# Altium schematic sheet Power.SchDoc
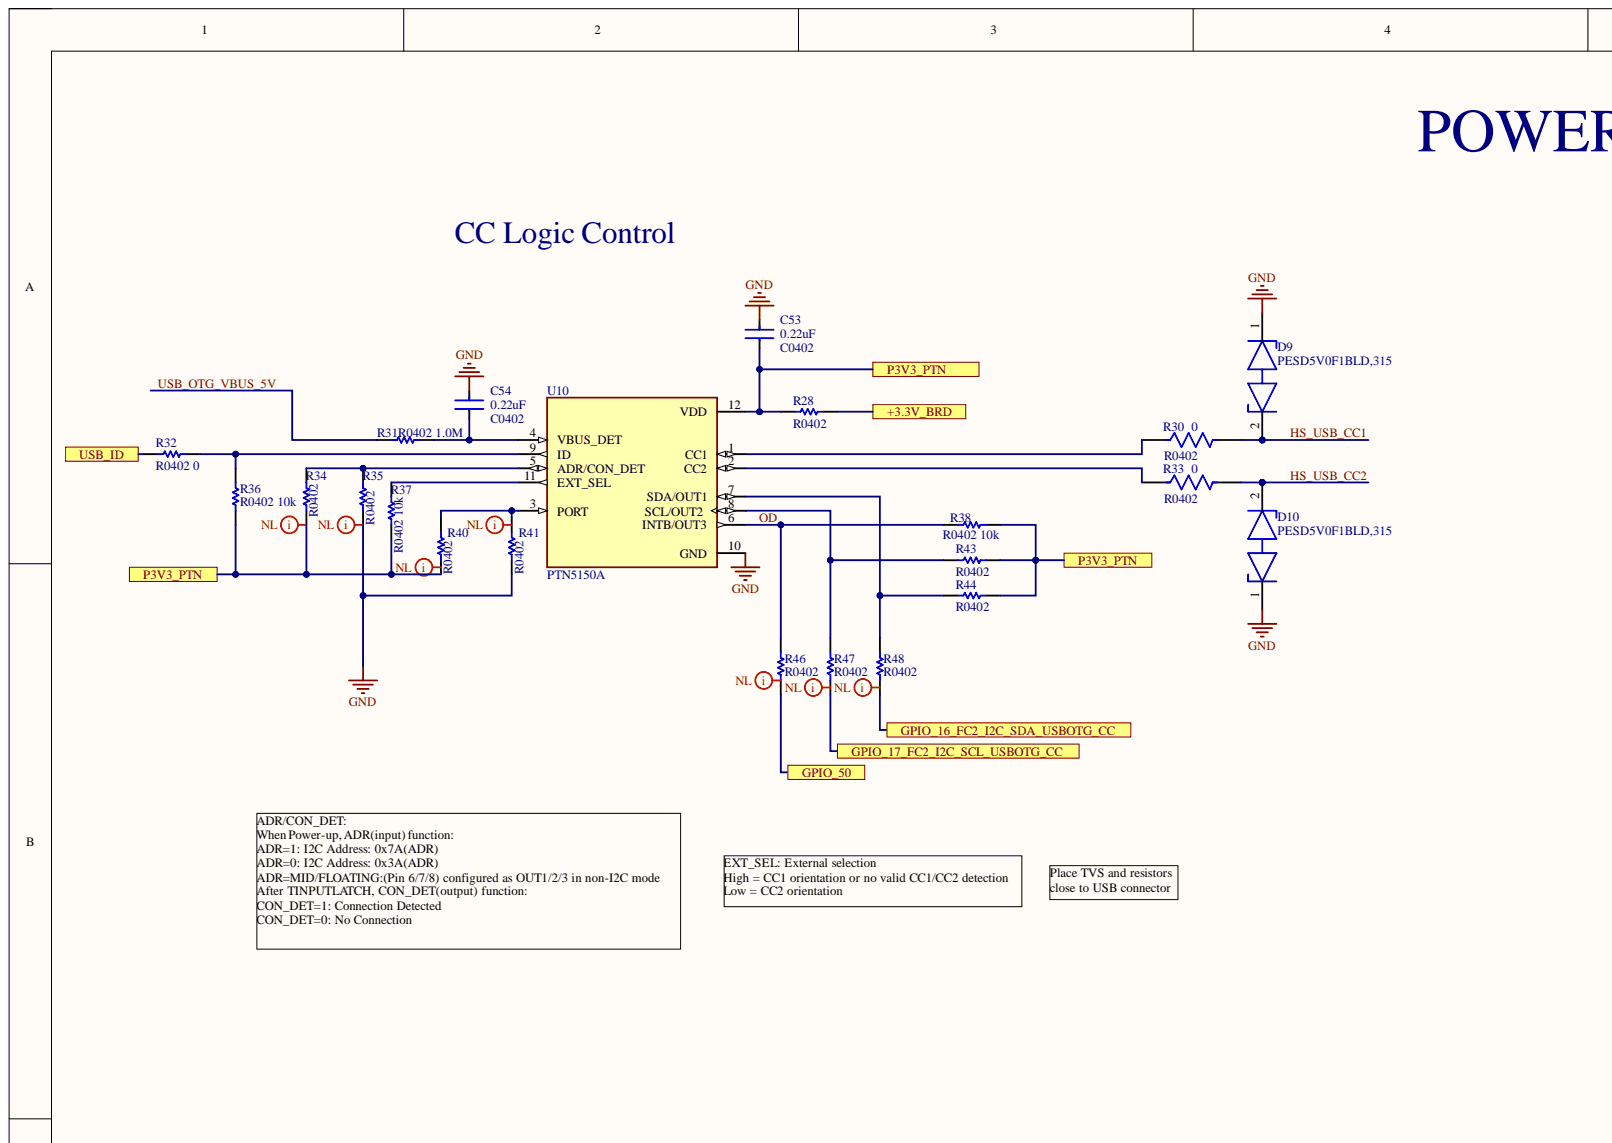
[diagram p0: Power.SchDoc, top left]
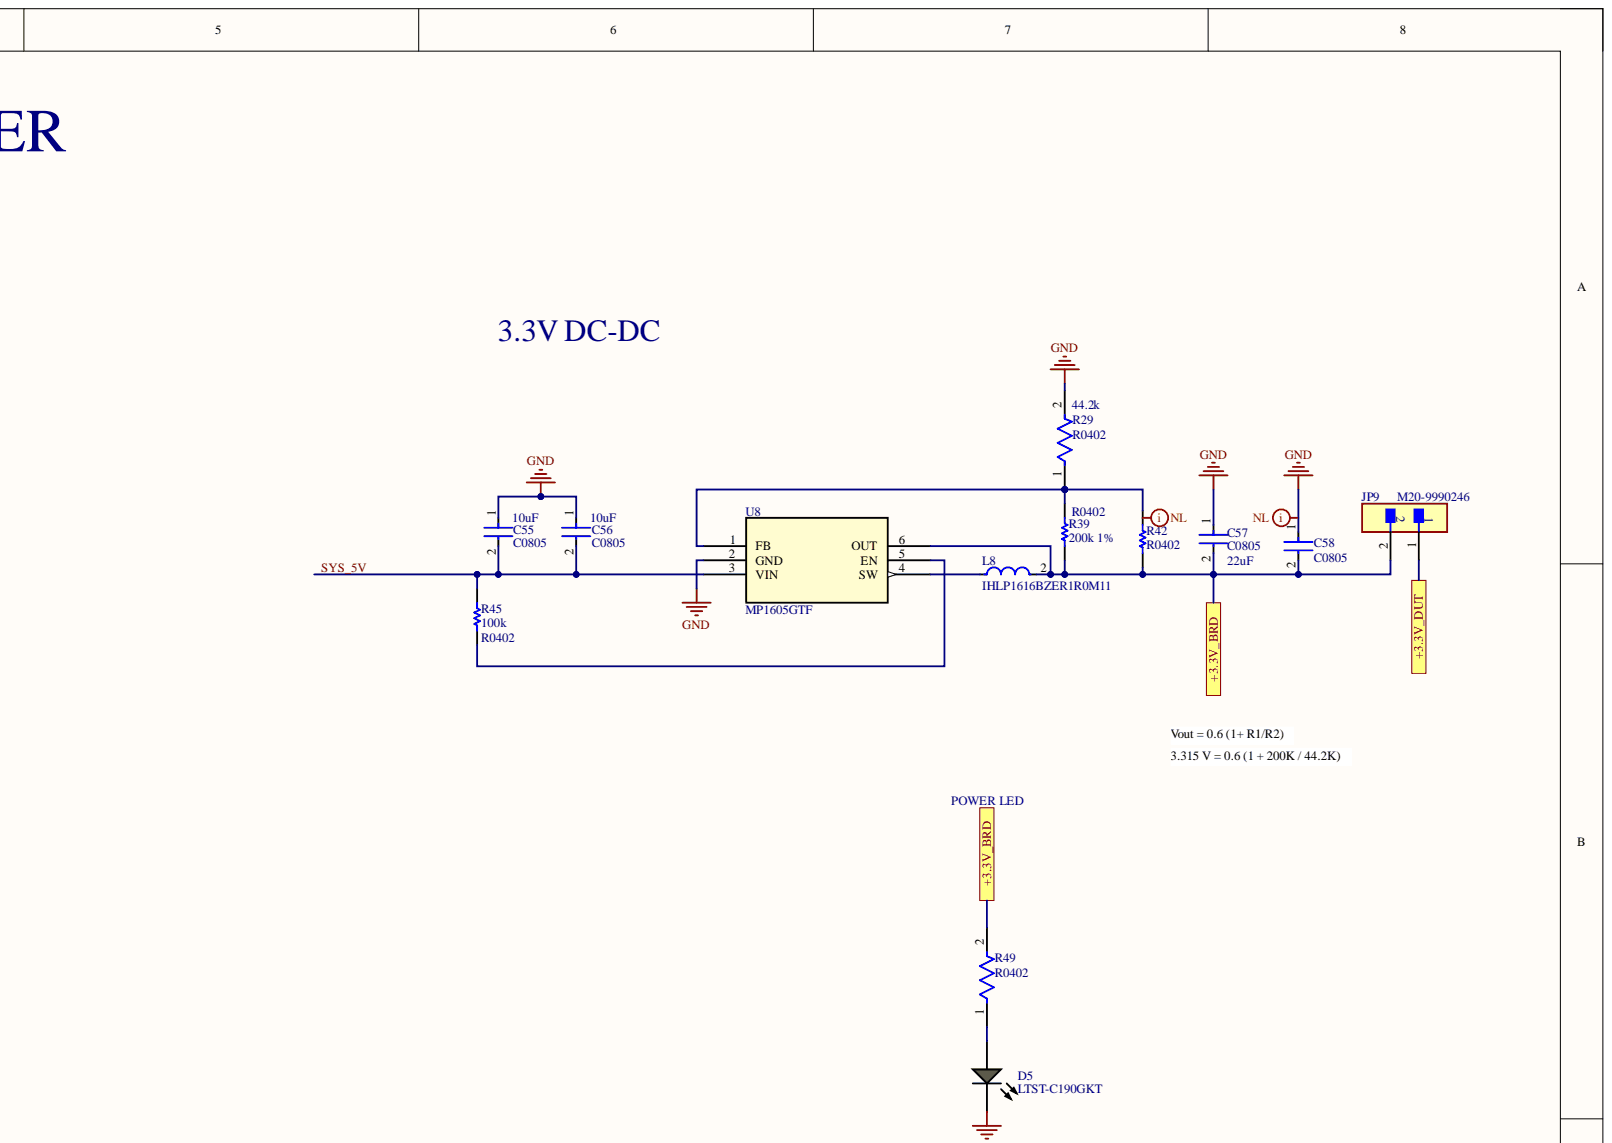
[diagram p1: Power.SchDoc, top right]
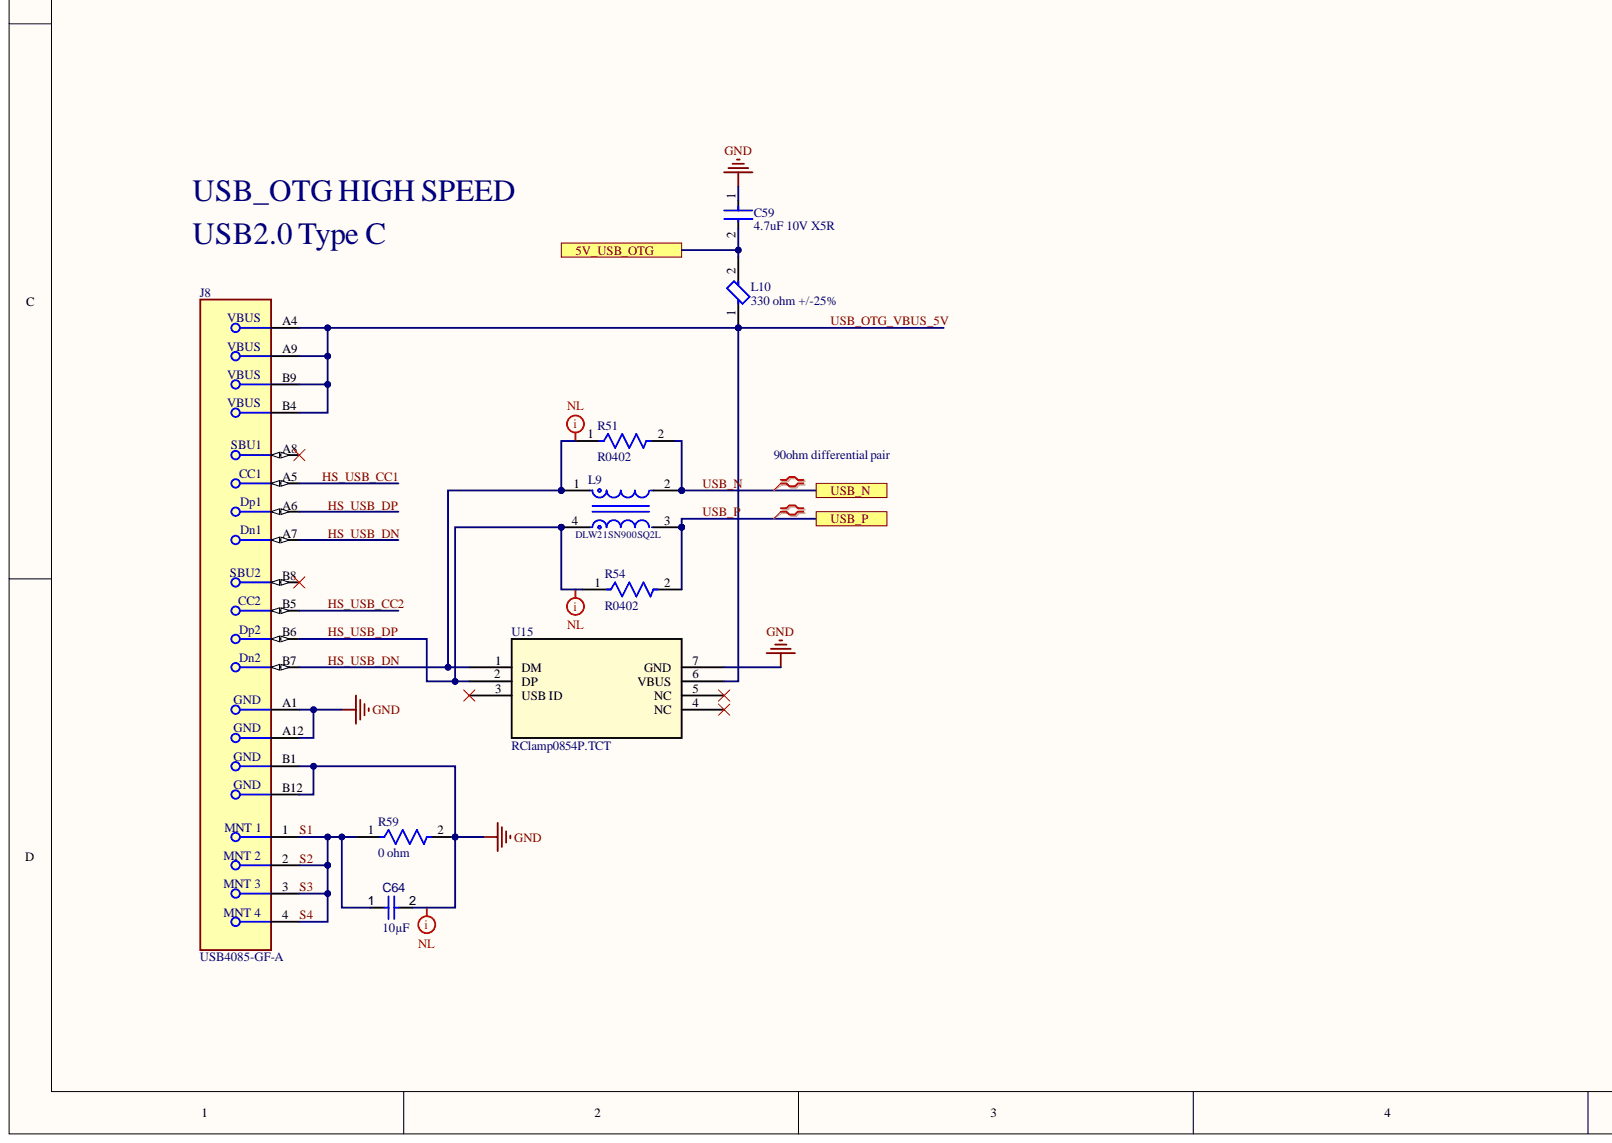
[diagram p2: Power.SchDoc, bottom left]
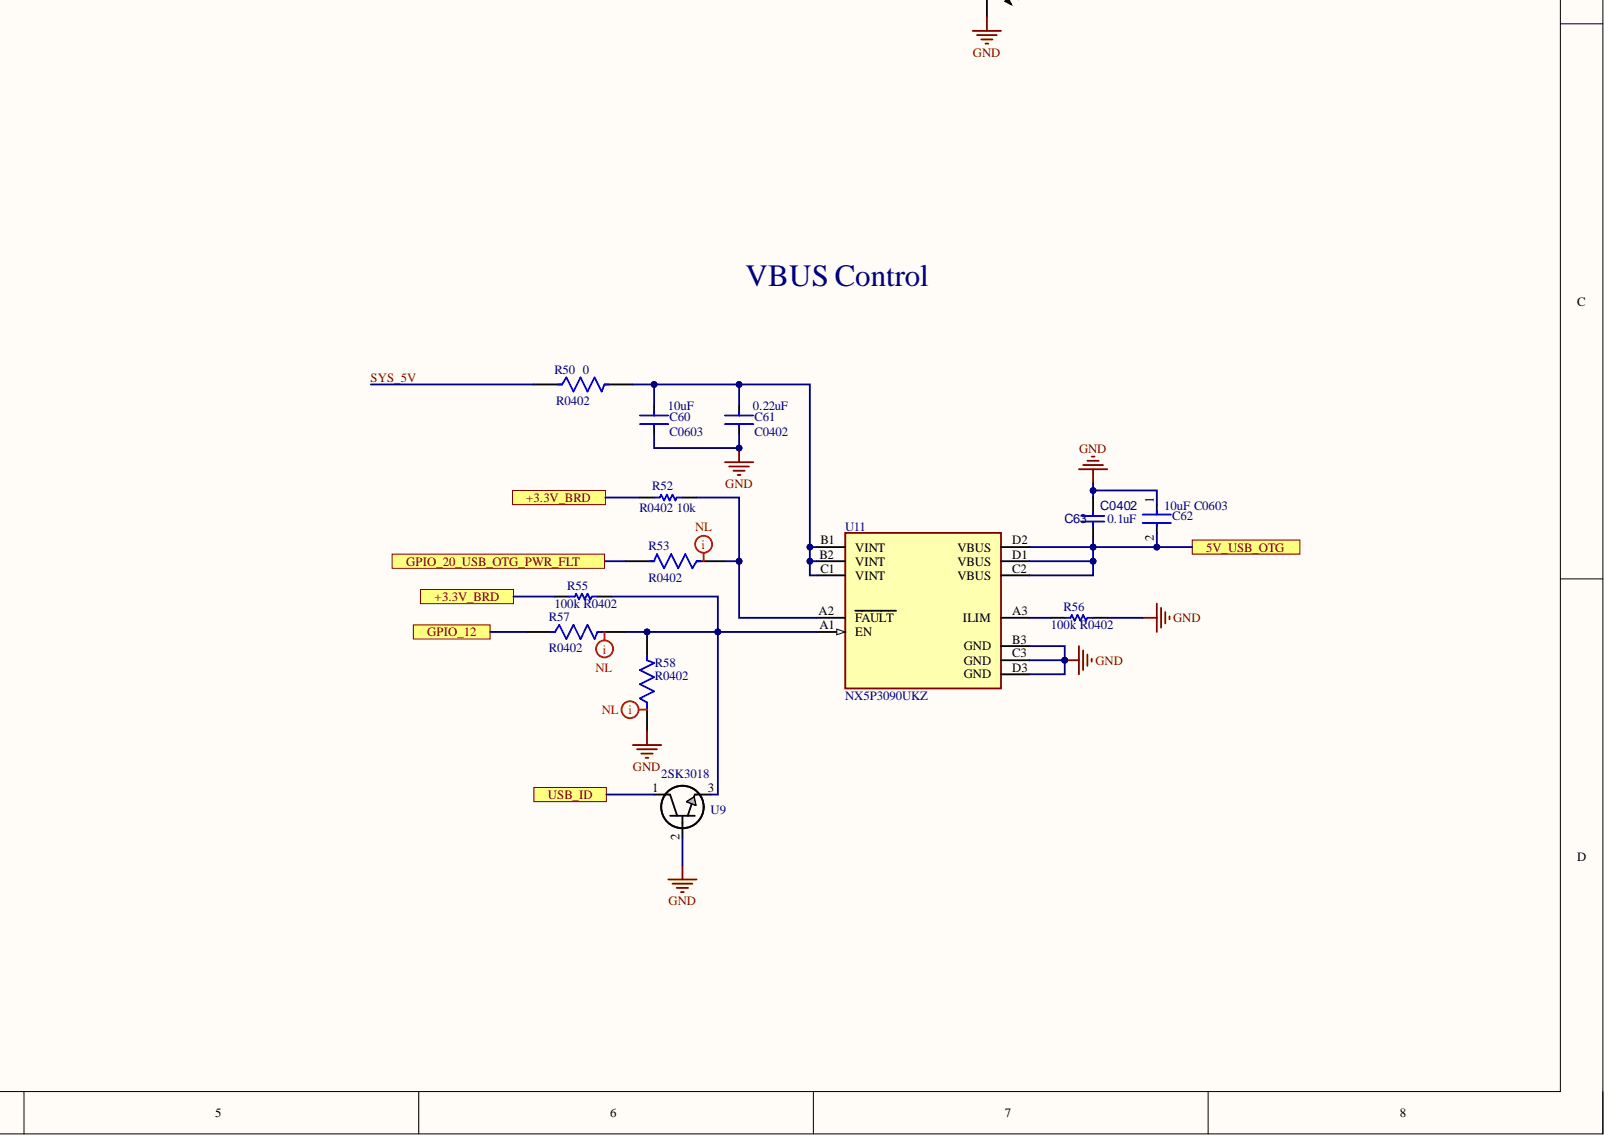
[diagram p3: Power.SchDoc, bottom right]

## Parts
C53  C0402  value 0.22uF  [FP-CC0402-MFG]
C54  C0402  value 0.22uF  [FP-CC0402-MFG]
C55  C0805  Capacitance: 10uF  [FP-CC0805-0_2-1_45-IPC_A]
C56  C0805  Capacitance: 10uF  [FP-CC0805-0_2-1_45-IPC_A]
C57  C0805  Capacitance: 22uF  [FP-C0805C226M9PACTU-MFG]
C58  C0805  [FP-C0805C226M9PACTU-MFG]
C59  4.7uF 10V X5R  Capacitance: 4.7uF  Voltage: 10V  [FP-C0805C475K8PACTU-MFG]
C60  C0603  Capacitance: 10uF  [FP-CC0603-DC-IPC_A]
C61  C0402  value 0.22uF  [FP-CC0402-MFG]
C62  10uF C0603  value 10uF  Capacitance: 10uF  [FP-C0603C106M9PACTU-MFG]
C63  C0402  value 0.1uF  [CAPC1005X56X25LL10T15]
C64  value 10µF  [CAPC2013X135X45NL10T25]  (hidden comment: Capacitor 10 uF +/- 10% 16 V 0805)
D5  LTST-C190GKT  [LTST-C190GKT_V]
D9  PESD5V0F1BLD,315  [FP-SOD882D-MFG]
D10  PESD5V0F1BLD,315  [FP-SOD882D-MFG]
J8  USB4085-GF-A  [FP-USB4085-GF-A-MFG]
JP9  M20-9990246  [FP-M20-9990246-MFG]
L8  IHLP1616BZER1R0M11  [FP-IHLP-1616BZ-FP_1_8545x-MFG]
L9  DLW21SN900SQ2L  [FP-DLW21S-MFG]
L10  330 ohm +/-25%  [FP-BLM18-0_15-t0_8-MFG]
R28  R0402  [RESC1005X40X25NL10T10]
R29  R0402  Resistance: 44.2k  [FP-CR0402-IPC_C]
R30  R0402  [FP-CR0402-IPC_B]
R31  R0402 1.0M  [RESC1005X40X25LL10T10]
R32  R0402 0  [RESC1005X40X25NL05T10]
R33  R0402  [FP-CR0402-IPC_B]
R34  R0402  [RESC1005X40X25NL05T10]
R35  R0402  [RESC1005X40X25NL05T10]
R36  R0402 10k  [RESC1005X40X25NL10T10]
R37  R0402 10k  [RESC1005X40X25NL10T10]
R38  R0402 10k  [RESC1005X40X25NL10T10]
R39  200k 1%  Part Number: R0402  [RESC1005X40X25LL10T10]
R40  R0402  [RESC1005X40X25NL05T10]
R41  R0402  [RESC1005X40X25NL05T10]
R42  R0402  [RESC1005X40X25NL10T10]
R43  R0402  [RESC1005X40X25NL10T10]
R44  R0402  [RESC1005X40X25NL10T10]
R45  100k  Part Number: R0402  [RESC1005X40X25LL10T10]
R46  R0402  [RESC1005X40X25NL10T10]
R47  R0402  [RESC1005X40X25NL10T10]
R48  R0402  [RESC1005X40X25NL10T10]
R49  R0402  [FP-CR0402-MFG]
R50  R0402  [FP-CR0402-IPC_B]
R51  R0402  [FP-CR0402-IPC_C]
R52  R0402 10k  [RESC1005X40X25NL10T10]
R53  R0402  [FP-CR0402-IPC_C]
R54  R0402  [FP-CR0402-IPC_C]
R55  100k R0402  [RESC1005X40X25LL10T10]
R56  100k R0402  [RESC1005X40X25LL10T10]
R57  R0402  [FP-CR0402-IPC_C]
R58  R0402  [FP-CR0402-IPC_C]
R59  0 ohm  [FP-CR0805-IPC_C]
U8  MP1605GTF  [MP1605GTF-Footprint-1]
U9  2SK3018  [2SK3018-Footprint-1]
U10  PTN5150A  [PTN5150A-Footprint-1]
U11  NX5P3090UKZ  [FP-SOT1390-5-IPC_C]
U15  RClamp0854P.TCT  [RClamp0854P.TCT-Footprint-1]

## Ports
5V_USB_OTG, +3.3V_BRD, +3.3V_DUT, GPIO_12, GPIO_16_FC2_I2C_SDA_USBOTG_CC, GPIO_17_FC2_I2C_SCL_USBOTG_CC, GPIO_20_USB_OTG_PWR_FLT, GPIO_50, P3V3_PTN, USB_ID, USB_N, USB_P

## Nets
GND: C53.1 C54.1 C55.1 C56.1 C57.1 C58.1 C59.1 C60.2 C61.2 C62.1 C63.1 C64.2 D5.2 D9.1 D10.1 J8.A1 J8.A12 J8.B1 J8.B12 R29.2 R35.1 R41.1 R56.2 R58.1 R59.2 U8.2(GND) U9.2 U10.10(GND) U11.B3(GND) U11.C3(GND) U11.D3(GND) U15.7(GND)
5V_USB_OTG: C59.2 C62.2 C63.2 L10.2 U11.C2(VBUS) U11.D1(VBUS) U11.D2(VBUS) ; port 5V_USB_OTG
+3.3V_BRD: C57.2 C58.2 JP9.2 L8.2 R28.1 R39.1 R42.1 R49.2 R52.1 R55.1 U8.6(OUT) ; port +3.3V_BRD
+3.3V_DUT: JP9.1 ; port +3.3V_DUT
GPIO_12: R57.1 ; port GPIO_12
GPIO_16_FC2_I2C_SDA_USBOTG_CC: R48.1 ; port GPIO_16_FC2_I2C_SDA_USBOTG_CC
GPIO_17_FC2_I2C_SCL_USBOTG_CC: R47.1 ; port GPIO_17_FC2_I2C_SCL_USBOTG_CC
GPIO_20_USB_OTG_PWR_FLT: R53.2 ; port GPIO_20_USB_OTG_PWR_FLT
GPIO_50: R46.1 ; port GPIO_50
HS_USB_CC1: D9.2 J8.A5 R30.2
HS_USB_CC2: D10.2 J8.B5 R33.2
HS_USB_DN: J8.A7 J8.B7 L9.1 R51.1 U15.1(DM)
HS_USB_DP: J8.A6 J8.B6 L9.4 R54.1 U15.2(DP)
OD: R38.1 R46.2 U10.6(INTB/OUT3)
P3V3_PTN: C53.2 R28.2 R34.1 R36.1 R37.1 R38.2 R40.1 R43.1 R44.1 U10.12(VDD) ; port P3V3_PTN
S1: C64.1 J8.1 J8.2 J8.3 J8.4 R59.1
SYS_5V: C55.2 C56.2 R45.1 R50.1 U8.3(VIN)
USB_ID: R32.1 U9.1 ; port USB_ID
USB_N: L9.2 R51.2 ; port USB_N
USB_OTG_VBUS_5V: J8.A4 J8.A9 J8.B4 J8.B9 L10.1 R31.1 U15.6(VBUS)
USB_P: L9.3 R54.2 ; port USB_P
NetC54_2: C54.2 R31.2 U10.4(VBUS_DET)
NetC60_1: C60.1 C61.1 R50.2 U11.B1(VINT) U11.B2(VINT) U11.C1(VINT)
NetD5_1: D5.1 R49.1
NetJ8_A8: J8.A8
NetJ8_B8: J8.B8
NetL8_1: L8.1 U8.4(SW)
NetR29_1: R29.1 R39.2 R42.2 U8.1(FB)
NetR30_1: R30.1 U10.1(CC1)
NetR32_2: R32.2 R36.2 U10.9(ID)
NetR33_1: R33.1 U10.2(CC2)
NetR34_2: R34.2 R35.2 U10.5(ADR/CON_DET)
NetR37_2: R37.2 U10.11(EXT_SEL)
NetR40_2: R40.2 R41.2 U10.3(PORT)
NetR43_2: R43.2 R47.2 U10.8(SCL/OUT2)
NetR44_2: R44.2 R48.2 U10.7(SDA/OUT1)
NetR45_2: R45.2 U8.5(EN)
NetR52_2: R52.2 R53.1 U11.A2(FAULT)
NetR55_2: R55.2 R57.2 R58.2 U9.3 U11.A1(EN)
NetR56_1: R56.1 U11.A3(ILIM)
NetU15_3: U15.3(USB_ID)
NetU15_4: U15.4(NC)
NetU15_5: U15.5(NC)

## Library data of the parts
C53 C54 C61 = CMP-03422-000154-1: CAP CER 0.22UF 10V X5R 0402; Manufacturer: YAGEO; Manufacturer Part Number: CC0402MRX5R6BB224; Automotive Grade: NA; Capacitance: 220 nF; Material: Ceramic; Temperature Coefficient: 30ppm/°C; Tolerance: 0.2; Automotive: No; Category: Cap; Component Kind: Standard; ComponentLink2Description: Datasheet; ComponentLink2URL: http://www.yageo.com/documents/recent/UPY-GP_NP0_16V-to-50V_16.pdf; Device Class L1: Passive Components; Device Class L2: Capacitors; Device Class L3: Ceramic Capacitors; Digikey Description: CAP CER 0.22UF 10V X5R 0402; Footprint Url: http://www.yageo.com/exep/pages/download/literatures/UPY-C_GEN_24.pdf; Height: 0.55mm; Ipc Land Pattern Name: CAPC10050X50; Lead Free: Yes; Library Name: Altium Content Vault; Mouser Description: Multilayer Ceramic Capacitors MLCC - SMD/SMT 220nF 10V X5R 20%; Octopart: https://octopart.com/cc0402mrx5r6bb224-yageo-57067692; Package: CC0402; RoHS: TRUE; Temperature: -55°C to +125°C; Temperature Characteristic: X5R; Temperature Range High: +125°C; Temperature Range Low: -55°C; Voltage Rating: 10 V
C55 C56 = CMP-03422-001501-1: CAP CER 10UF 25V X5R 0805; Tolerance: 10%; Case Code (Imperial): 0805; Case Code (Metric): 2012; Case/Package: 0805; Component Kind: Standard; Depth: 1.25mm; Dielectric: X5R; Halogen Free: Halogen Free; Lead Free: Lead Free; Length: 0.079inch; Library Name: Altium Content Vault; Max Operating Temperature: 85°C; Min Operating Temperature: -55°C; Mount: Surface Mount; Packaging: Tape and Reel; Resistor Type: Ceramic; RoHS Compliant: Yes; Series: CC; Termination: SMD/SMT; Thickness: 0.057inch; Voltage Rating: 25V; Voltage Rating (DC): 25V; Weight: 0.000194oz; Width: 0.049inch
C57 C58 = CMP-2007-01021-2: CAP CER 22UF 6.3V X5R 0805; Dielectric Material: Ceramic; Dissipation Factor: 5%; Tolerance: 20%; Case Code (Imperial): 0805; Case Code (Metric): 2012; Case/Package: 0805; Component Kind: Standard; Construction: Flat; Depth: 1.25mm; Dielectric: X5R; Height: 1.25mm; Lead Free: Lead Free; Length: 2mm; Library Name: Altium Content Vault; Max Operating Temperature: 85°C; Min Operating Temperature: -55°C; Mount: Surface Mount; Number of Pins: 2; Package Quantity: 2500; Packaging: Tape and Reel; Radiation Hardening: No; REACH SVHC: No SVHC; RoHS Compliant: Yes; Termination: SMD/SMT; Voltage: 6.3V; Voltage Rating: 6.3V; Voltage Rating (DC): 6.3V; Width: 1.2mm
  C58: Capacitance: 22uF
C59 = CMP-2007-01007-2: CAP CER 4.7UF 10V X5R 0805; Dielectric Material: Ceramic; Dissipation Factor: 5%; Tolerance: 10%; Case Code (Imperial): 0805; Case Code (Metric): 2012; Case/Package: 0805; Component Kind: Standard; Construction: Flat; Depth: 1.25mm; Dielectric: X5R; Height: 1.25mm; Lead Free: Lead Free; Lead Pitch: 0.75mm; Length: 2mm; Library Name: Altium Content Vault; Max Operating Temperature: 85°C; Min Operating Temperature: -55°C; Mount: Surface Mount; Number of Pins: 2; Package Quantity: 1; Packaging: Tape and Reel; Radiation Hardening: No; REACH SVHC: No SVHC; RoHS Compliant: Yes; Series: C; Termination: SMD/SMT; Thickness: 0.055inch; Voltage Rating: 10V; Voltage Rating (DC): 10V; Width: 1.2mm
C60 = CMP-03422-001507-1: CAP CER 10UF 10V X5R 0603; Tolerance: 10%; Case Code (Imperial): 0603; Case Code (Metric): 1608; Case/Package: 0603; Component Kind: Standard; Depth: 0.8mm; Dielectric: X5R; Halogen Free: Halogen Free; Lead Free: Lead Free; Length: 0.063inch; Library Name: Altium Content Vault; Max Operating Temperature: 85°C; Min Operating Temperature: -55°C; Mount: Surface Mount; Package Quantity: 4000; Packaging: Tape and Reel; Resistor Type: Ceramic; RoHS Compliant: Yes; Termination: SMD/SMT; Thickness: 0.035inch; Voltage Rating: 10V; Voltage Rating (DC): 10V; Weight: 7.1E-05oz; Width: 0.031inch
C62 = CMP-2006-02285-3: CAP CER 10UF 6.3V X5R 0603; Manufacturer: Kemet; Manufacturer Part Number: C0603C106M9PACTU; Material: Ceramic; Temperature Coefficient: 15%; Tolerance: 20%; Automotive: No; Category: Cap; Component Kind: Standard; ComponentLink2Description: Datasheet; ComponentLink2URL: http://www.kemet.com/Lists/ProductCatalog/Attachments/19/KEM_C1006_X5R_SMD.pdf; Device Class L1: Passive Components; Device Class L2: Capacitors; Device Class L3: Ceramic Capacitors; Digikey Description: CAP CER 10UF 6.3V X5R 0603; Height: 0.9mm; Ipc Land Pattern Name: CAPC16080X80; Lead Free: Yes; Library Name: Altium Content Vault; Mouser Description: In a Pack of 10, KEMET 0603 (1608M) 10µF MLCC 6.3V dc ±20% SMD C0603C106M9PACTU; Octopart: https://octopart.com/c0603c106m9pactu-kemet-8375727; Package: C0603C106M9PACTU; RoHS: TRUE; Temperature: -55°C to +85°C; Temperature Characteristic: X5R; Temperature Range High: +85°C; Temperature Range Low: -55°C; Voltage Rating: 6.3V
C63 = CMP-001-00001-6: Chip Capacitor, 0.1 uF, +/- 5%, 16 V, 0402 (1005 Metric); Capacitor Type: Ceramic; Voltage Rating: 16V; Case/Package: 0402; Component Kind: Standard; Library Name: Altium Personal Space; Max Operating Temperature: 125°C; Min Operating Temperature: -55°C; Mounting Technology: SM; Pins: 2; RoHS Compliant: True; Tolerance: 5%
C64 = CMP-001-00015-6: Chip Capacitor, 10 uF, +/- 10%, 16 V, 0805 (2012 Metric); Capacitor Type: Ceramic; Voltage Rating: 16V; Case/Package: 0805; Component Kind: Standard; Library Name: Altium Personal Space; Max Operating Temperature: 85°C; Min Operating Temperature: -55°C; Mounting Technology: SM; Pins: 2; RoHS Compliant: True; Tolerance: 10%
D5 = CMP-007-00022-2: LED Uni-Color Green, 100 mW, 30 mA, -55 to 85 degC, 2-Pin SMD, RoHS, Tape and Reel; Manufacturer: Lite-On; Forward Current: 20mA; Forward Voltage: 2.1V; Lens Style: Clear; Luminous Intensity: 6mcd; Power: 100mW; Viewing Angle: 130degrees; Case/Package: 0603; Colour: Green; Component Kind: Standard; Datasheet URL: http://datasheet.octopart.com/LTST-C190GKT-Lite-On-datasheet-158530.pdf; Datasheet Version: Dec-06; Illumination Colour: Green; Library Name: Altium Personal Space; Manufacturer URL: http://www.us.liteon.com/; Max Operating Temperature: 85°C; Min Operating Temperature: -55°C; Mounting Technology: SM; Nominal Vf: 2.1V; Package Description: 2-Pin Surface Mount Device, Body 1.6 x 0.8 mm; Package Quantity: 3000; Package Reference: C190GKT; Packaging: TapeandReel; Pins: 2; RoHS Compliant: True
D9 D10 = CMP-14432-000003-1: TVS DIODE 5.5V 15V 2DFN1006D; Component Kind: Standard; Library Name: Altium Content Vault; RoHS Compliant: Yes
J8 = CMP-002-00075-1: Gct (global Connector Technology) - USB4085-GF-A - Usb Connector, 2.0 Type C, Rcpt, 16POS; Component Kind: Standard; Library Name: Altium Personal Space
JP9 = CMP-15831-000009-1: CONN HEADER VERT 2POS 2.54MM; Manufacturer: Harwin; Conn Gender: Male; Conn Orientation: Vertical; Current Rating: 3A; Number Of Contacts: 2; Automotive: No; Category: Conn; Component Kind: Standard; ComponentLink2Description: Datasheet; ComponentLink2URL: https://cdn.harwin.com/pdfs/M20-999.pdf; Contact Material: Tin,Nickel; Device Class L1: Connectors; Device Class L2: Headers and Wire Housings; Device Class L3: unset; Digikey Description: CONN HEADER VERT 2POS 2.54MM; Height: 8.99mm; Lead Free: Yes; Library Name: Altium Content Vault; Manufacturer Part Number: M20-9990246; Mouser Description: 2.54mm (0.1") Pitch SIL Vertical PC Tail Pin Header, 6.1mm mating pin height, tin, 2 contacts; Number Of Rows: 1; Octopart: https://octopart.com/m20-9990246-harwin-2590635; Package: M20-9990246; Pitch: 2.54mm; RoHS: TRUE; Temperature: -40°C to +105°C; Temperature Range High: +105°C; Temperature Range Low: -40°C
L8 = CMP-02424-000223-1: Fixed Inductors Commercial Low DCR Series SMT 1uH 4.5A 27mΩ 20%; Core Material: Ferrite; DC Resistance (DCR): 27mR; Saturation Current: 5A; Self Resonant Frequency: 64MHz; Shielding: Shielded; Test Frequency: 100kHz; Case Code (Imperial): 1616; Case Code (Metric): 4040; Case/Package: 1616; Component Kind: Standard; Contact Plating: Tin; Current Rating: 4.5A; Depth: 4.31mm; Height: 2mm; Height - Seated (Max): 0.079inch; Inductance: 1uH; Inductance Tolerance: 20%; Lead Free: Lead Free; Length: 4.7mm; Library Name: Altium Content Vault; Max DC Current: 4.5A; Max Operating Temperature: 125°C; Military Standard: Not; Min Operating Temperature: -55°C; Mount: Surface Mount; Number of Pins: 1616; Package Quantity: 4000; Packaging: Tape and Reel; Radiation Hardening: No; RMS Current (Irms): 4.5A; Termination: SMD/SMT; Tolerance: 20%; Width: 0.16inch
L9 = CMP-2000-05264-2: Common Mode Choke, 0805, 90Ω @ 100MHz, 0.35Ω, 25%, 330mA; DC Resistance (DCR): 350mR; Impedance: 90R; Insulation Resistance: 10MR; Number of Lines: 2; Shielding: Unshielded; Voltage Rating: 50V; Case Code (Imperial): 0805; Case/Package: 0805; Component Kind: Standard; Current: 330mA; Current Rating: 330mA; DC Current: 330mA; Depth: 1.4mm; Height: 0.055inch; Lead Free: Lead Free; Length: 2mm; Library Name: Altium Content Vault; Max DC Current: 330mA; Max Operating Temperature: 85°C; Military Standard: Not; Min Operating Temperature: -40°C; Mount: Surface Mount; Package Quantity: 1; Packaging: Tape and Reel; Radiation Hardening: No; REACH SVHC: No SVHC; Resistor Type: Wirewound; Series Resistance: 350mR; Termination: SMD/SMT; Test Frequency: 100MHz; Tolerance: 25%; Weight: 0.000388oz; Width: 0.047inch
L10 = CMP-0686-00563-7: Chip Ferrite Bead, 0603, 330Ω @ 100MHz, 0.08Ω, 25%, 1.7A; DC Resistance (DCR): 80mR; Impedance: 330R; Number of Circuits: 1; Case Code (Imperial): 0603; Case Code (Metric): 1608; Case/Package: 0603; Component Kind: Standard; Core Material: Ferrite; Current Rating: 1.7A; DC Resistance-Max: 80mR; Depth: 0.95mm; Height: 0.8mm; Inductor Type: FERRITE BEAD; Lead Free: Lead Free; Length: 1.6mm; Library Name: Altium Content Vault; Material: Ferrite; Max Current Rating: 1.7A; Max DC Current: 1.7A; Max Operating Temperature: 125°C; Min Operating Temperature: -55°C; Number of Lines: 1; Number of Pins: 2; Package Quantity: 1; Packaging: Tape and Reel; REACH SVHC: No SVHC; Series: BLM18K; Termination: SMD/SMT; Test Frequency: 100MHz; Tolerance: 25%; Weight: 7.1E-05oz; Width: 0.8mm
R28 R36-R38 R42-R44 R46-R48 R52 = CMP-2002-04694-1: Manufacturer: Bourns; Part Number: CR0402-FX-1002GLF; Component Kind: Standard; Library Name: Altium Content Vault
R29 = CMP-2002-05198-2: Thick Film Chip Resistors 0402 44.2kΩ 0.0625W 1% 100ppm/°C; Max Power Dissipation: 63mW; Case/Package: 0402; Component Kind: Standard; Height: 0.016inch; Length: 0.039inch; Library Name: Altium Content Vault; Max Operating Temperature: 155°C; Min Operating Temperature: -55°C; Mount: Surface Mount; Number of Terminations: 2; Package Quantity: 10000; Packaging: Tape and Reel; Resistor Type: Thick Film; RoHS Compliant: Yes; Series: CR0402; Temperature Coefficient: 100ppm/°C; Thickness: 356um; Tolerance: 1%; Width: 0.02inch
R30 R33 R50 = CMP-2002-05495-2: Thick Film Chip Resistors 0402 0Ω 0.0625W 5%; Max Power Dissipation: 63mW; Power Rating: 62.5mW; Resistance: 0; Temperature Coefficient: 500ppm/°C; Voltage Rating: 50V; Case Code (Imperial): 0402; Case Code (Metric): 1005; Case/Package: 0402; Component Kind: Standard; Composition: Thick Film; Contact Plating: Tin; Depth: 0.5mm; Height: 0.35mm; Length: 1mm; Library Name: Altium Content Vault; Max Operating Temperature: 125°C; Min Operating Temperature: -55°C; Mount: Surface Mount; Number of Pins: 2; Package Quantity: 10000; Packaging: Tape and Reel; Radiation Hardening: No; REACH SVHC: No SVHC; Termination: SMD/SMT; Thickness: 356um; Tolerance: 5%; Voltage Rating (DC): 50V; Width: 0.5mm
R31 = CMP-2002-04698-1: Manufacturer: Bourns; Part Number: CR0402-FX-1004GLF; Component Kind: Standard; Library Name: Altium Content Vault
R32 R34 R35 R40 R41 = CMP-1011-00001-2: Manufacturer: Yageo / Phycomp; Part Number: RC0402JR-070RL; Component Kind: Standard; Library Name: Altium Content Vault
R39 = CMP-2002-04904-1: Manufacturer: Bourns; Component Kind: Standard; Library Name: Altium Content Vault
R45 = CMP-2002-05523-1: Manufacturer: Bourns; Component Kind: Standard; Library Name: Altium Content Vault
R49 = CMP-1011-00473-3: Thick Film Chip Resistors 0402 470Ω 0.0625W 1% 100ppm/°C; Max Power Dissipation: 63mW; Power Rating: 62.5mW; Resistance: 470R; Voltage Rating: 50V; Case Code (Imperial): 0402; Case Code (Metric): 1005; Case/Package: 0402; Component Kind: Standard; Composition: Thick Film; Contact Plating: Tin; Depth: 0.5mm; Height: 0.016inch; Length: 1mm; Library Name: Altium Content Vault; Max Operating Temperature: 125°C; Military Standard: Not; Min Operating Temperature: -55°C; Mount: Surface Mount; Number of Terminations: 2; Package Quantity: 10000; Packaging: Tape and Reel; Radiation Hardening: No; Temperature Coefficient: 100ppm/°C; Termination: SMD/SMT; Thickness: 356um; Tolerance: 1%; Width: 0.02inch
R51 R53 R54 R57 R58 = CMP-2002-04898-2: Thick Film Chip Resistors 0402 200Ω 0.0625W 1% 100ppm/°C; Max Power Dissipation: 63mW; Power Rating: 62.5mW; Resistance: 200R; Voltage Rating: 50V; Case Code (Imperial): 0402; Case Code (Metric): 1005; Case/Package: 0402; Component Kind: Standard; Composition: Thick Film; Contact Plating: Tin; Depth: 0.5mm; Height: 0.016inch; Length: 1mm; Library Name: Altium Content Vault; Max Operating Temperature: 125°C; Military Standard: Not; Min Operating Temperature: -55°C; Mount: Surface Mount; Number of Terminations: 2; Package Quantity: 10000; Packaging: Tape and Reel; Radiation Hardening: No; Temperature Coefficient: 100ppm/°C; Termination: SMD/SMT; Thickness: 356um; Tolerance: 1%; Width: 0.5mm
R55 R56 = CMP-2002-05523-1: Manufacturer: Bourns; Part Number: CR0402-JW-104GLF; Component Kind: Standard; Library Name: Altium Content Vault
R59 = CMP-07231-004348-1: Thick Film Chip Resistors 0805 0Ω 0.125W 5%; Max Power Dissipation: 125mW; Resistance: 0mR; Temperature Coefficient: 500ppm/°C; Voltage Rating: 150V; Case Code (Imperial): 0805; Case Code (Metric): 2012; Case/Package: 0805; Component Kind: Standard; Composition: Thick Film; Contact Plating: Tin; Depth: 1.25mm; Height: 0.5mm; Length: 2mm; Library Name: Altium Content Vault; Max Operating Temperature: 155°C; Military Standard: Not; Min Operating Temperature: -55°C; Mount: Surface Mount; Number of Pins: 2; Package Quantity: 5000; Packaging: Tape and Reel; Power Rating: 125mW; Radiation Hardening: No; REACH SVHC: No SVHC; Termination: SMD/SMT; Thickness: 508um; Tolerance: 5%; Voltage Rating (DC): 150V; Width: 1.25mm
U8 = CMP-019-00049-1: monolithic, step-down, switchmode converter with built-in, internal power; Component Kind: Standard; Library Name: Altium Personal Space
U9 = CMP-013-00034-1: N-Channel Enhancement Mode Field Effect Transistor; Component Kind: Standard; Library Name: Altium Personal Space
U10 = CMP-015-00008-1: small thin low power CC Logic chip supporting the USB Type-C connector; Component Kind: Standard; Library Name: Altium Personal Space
U11 = CMP-10136-000001-1: IC PWR SWITCH 1:1 12WLCSP; Manufacturer: NXP Semiconductors; Max Junction Temp: +150°C; Max Power Dissipation: 910mW; Max Supply Voltage: 5.5V; Min Supply Voltage: 2.5V; Nominal Supply Current: 196-210uA; Number Of Outputs: 1; Output Current: 3A; Output Voltage: 5V; Standoff Height: 0.17mm; Ambient Temperature Range High: +85°C; Ambient Temperature Range Low: -40°C; Automotive: No; Category: IC; Component Kind: Standard; ComponentLink2Description: Datasheet; ComponentLink2URL: https://www.nxp.com/docs/en/data-sheet/NX5P3090.pdf; Device Class L1: Integrated Circuits (ICs); Device Class L2: Power Management ICs; Device Class L3: unset; Digikey Description: IC PWR SWITCH 1:1 12WLCSP; Footprint Url: https://www.nxp.com/docs/en/package-information/SOT1390-5.pdf; Height: 0.565mm; Ipc Land Pattern Name: BGA12N40P3X4_165X135X52; Lead Free: Yes; Library Name: Altium Content Vault; Manufacturer Part Number: NX5P3090UKZ; Octopart: https://octopart.com/nx5p3090ukz-nxp+semiconductors-74624074; Package: SOT1390-5; RoHS: TRUE; Temperature: -40°C to +150°C; Temperature Range High: +150°C; Temperature Range Low: -40°C
U15 = CMP-004-00031-1: a low capacitance TVS array designed to; Component Kind: Standard; Library Name: Altium Personal Space
